# Altium schematic sheet USBDM_SWD_JS16CWJ.SchDoc(USBDM_SWD_JS16CWJ)
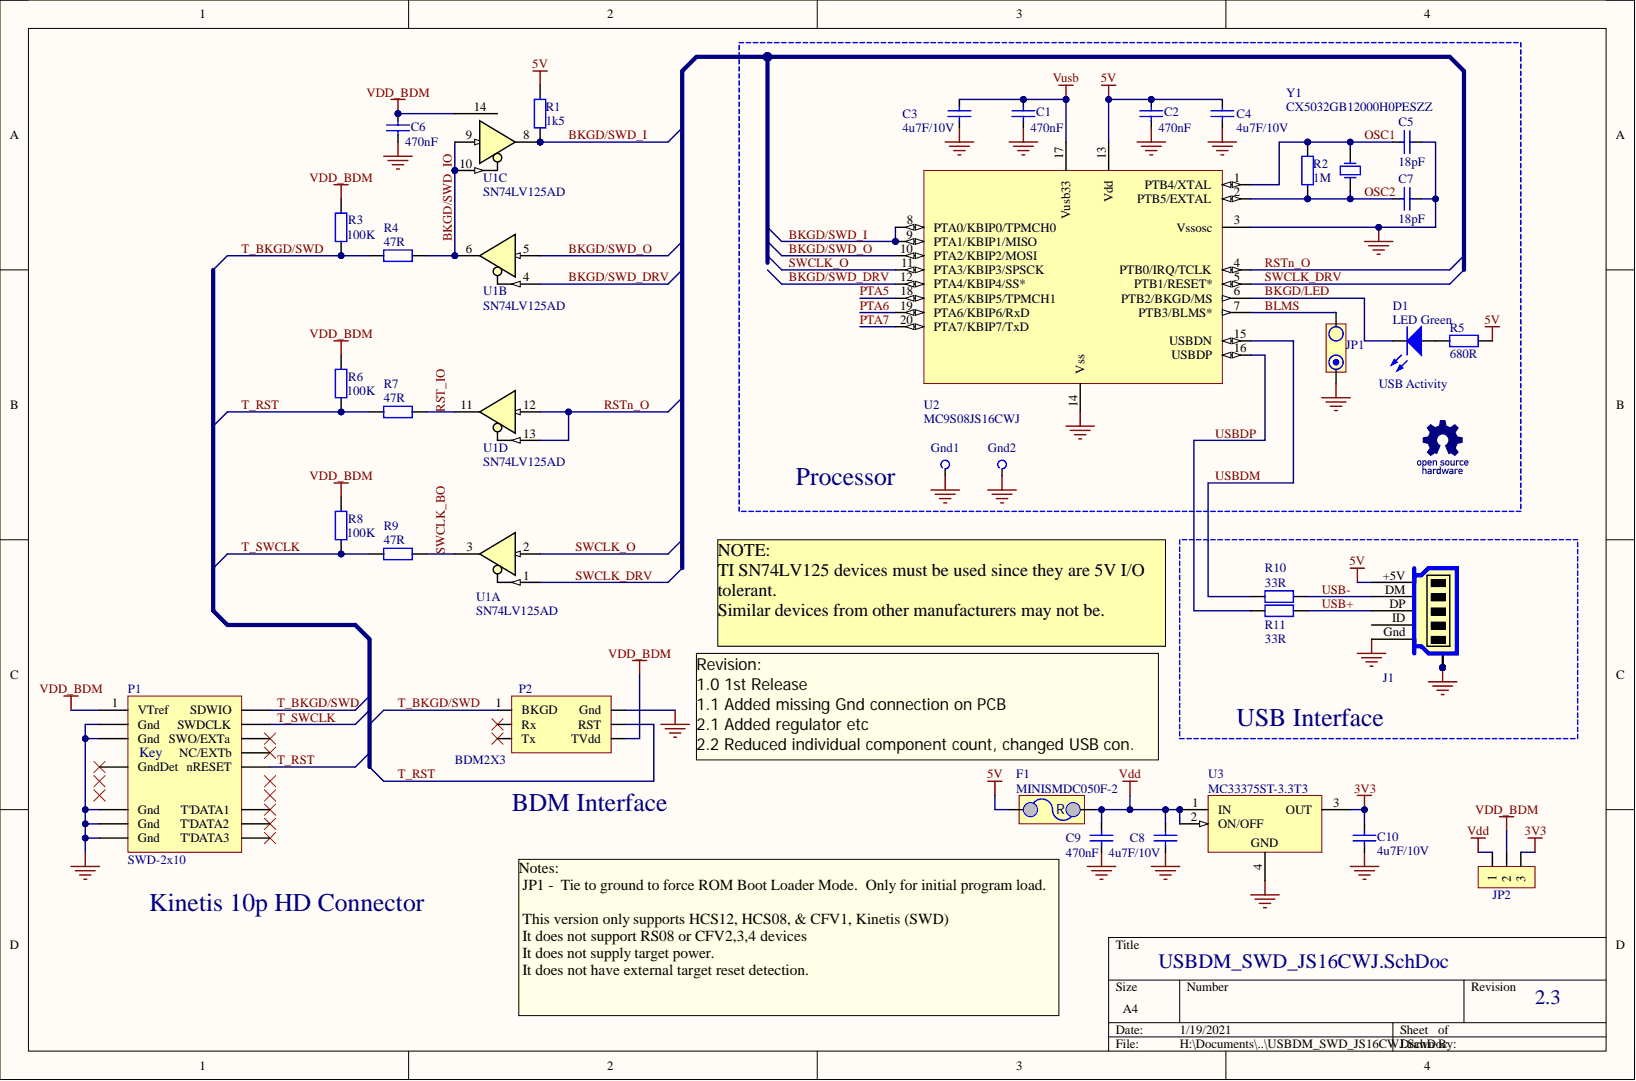
[diagram p0: USBDM_SWD_JS16CWJ.SchDoc]

## Parts
C1  470nF  [CCNP2012-0805]
C2  470nF  [CCNP2012-0805]
C3  4u7F/10V  [CCNP2012-0805]
C4  4u7F/10V  [CCNP2012-0805]
C5  18pF  [CCNP2012-0805]
C6  470nF  [CCNP2012-0805]
C7  18pF  [CCNP2012-0805]
C8  4u7F/10V  [CCNP2012-0805]
C9  470nF  [CCNP2012-0805]
C10  4u7F/10V  [CCNP2012-0805]
D1  LED Green  Class II: LED  [LED2012-0805-GREEN]
F1  MINISMDC050F-2  [PTC4532-1812]
Gnd1  TP  [PCBPIN - 0.9mm]  (value not drawn)  (no BOM)
Gnd2  TP  [PCBPIN - 0.9mm]  (value not drawn)  (no BOM)
J1  USB_MICRO_B_CONNECTOR  [MOLEX - 47346-0001]  (value not drawn)
JP1  PIN2X1  [HDR1X2S]  (value not drawn)
JP2  Header 1x3 2mm  [Header 1x3 (2mm)]  (value not drawn)
Logo1  OSHW Logo  [OSHW]  (value not drawn)  (no BOM)
P1  SWD-2x10  [SWD-2x10 - reduced]
P2  BDM2X3  [BDM]
R1  1k5  [CR2012-0805]
R2  1M  [CR2012-0805]
R3  100K  [CR2012-0805]
R4  47R  [CR2012-0805]
R5  680R  [CR2012-0805]
R6  100K  [CR2012-0805]
R7  47R  [CR2012-0805]
R8  100K  [CR2012-0805]
R9  47R  [CR2012-0805]
R10  33R  [CR2012-0805]
R11  33R  [CR2012-0805]
U1  SN74LV125AD  [SO-14_L]  (parts A,B,C,D)
U2  MC9S08JS16CWJ  [SO-G20]
U3  MC33375ST-3.3T3  [SOT223]
Y1  CX5032GB12000H0PESZZ  [XTAL_SMT - 5x3.2]

## Nets
3V3: C10.2 JP2.3 U3.3(OUT)
5V: C2.2 C4.2 F1.1 J1.VBUS R1.2 R5.2 U2.13(Vdd)
GND: C1.1 C2.1 C3.1 C4.1 C5.1 C6.1 C7.1 C8.1 C9.1 C10.1 Gnd1.1 Gnd2.1 J1.G J1.S1 J1.S2 J1.S3 J1.S4 J1.S5 J1.S6 JP1.1 P1.3(Gnd) P1.5(Gnd) P1.15(Gnd) P1.17(Gnd) P1.19(Gnd) P2.2(Gnd) U1.7 U2.3(Vssosc) U2.14(Vss) U3.4
Vdd: C8.2 C9.2 F1.2 JP2.1 U3.1(IN) U3.2(ON/OFF)
VDD_BDM: C6.2 JP2.2 P1.1(VTref) P2.6(TVdd) R3.1 R6.1 R8.1 U1.14
Vusb: C1.2 C3.2 U2.17(Vusb33)
BKGD/LED: D1.K U2.6(PTB2/BKGD/MS)
BKGD/SWD_DRV: U1.4 U2.12(PTA4/KBIP4/SS*)
BKGD/SWD_I: R1.1 U1.8 U2.8(PTA0/KBIP0/TPMCH0) U2.9(PTA1/KBIP1/MISO)
BKGD/SWD_IO: R4.1 U1.6 U1.9 U1.10
BKGD/SWD_O: U1.5 U2.10(PTA2/KBIP2/MOSI)
BLMS: JP1.2 U2.7(PTB3/BLMS*)
OSC1: C5.2 R2.2 U2.1(PTB4/XTAL) Y1.2
OSC2: C7.2 R2.1 U2.2(PTB5/EXTAL) Y1.1
PTA5: U2.18(PTA5/KBIP5/TPMCH1)
PTA6: U2.19(PTA6/KBIP6/RxD)
PTA7: U2.20(PTA7/KBIP7/TxD)
RST_IO: R7.1 U1.11
RSTn_O: U1.12 U1.13 U2.4(PTB0/IRQ/TCLK)
SWCLK_BO: R9.1 U1.3
SWCLK_DRV: U1.1 U2.5(PTB1/RESET*)
SWCLK_O: U1.2 U2.11(PTA3/KBIP3/SPSCK)
T_BKGD/SWD: P1.2(SDWIO) P2.1(BKGD) R3.2 R4.2
T_RST: P1.10(nRESET) P2.4(RST) R6.2 R7.2
T_SWCLK: P1.4(SWDCLK) R8.2 R9.2
USB+: J1.D+ R11.1
USB-: J1.D- R10.1
USBDM: R10.2 U2.15(USBDN)
USBDP: R11.2 U2.16(USBDP)
NetD1_A: D1.A R5.1

Not connected: J1 ID; P1 6(SWO/EXTa) 8(NC/EXTb) 9(GndDet) 16(T'DATA1) 18(T'DATA2) 20(T'DATA3); P2 3(Rx) 5(Tx)

## Library data of the parts
C1 C2 C5-C7 C9 = Cap: Capacitor SMT 0805; Manufacturer: Generic Components; Class: Passive; Component Kind: Standard; Library Name: MyLibrary.IntLib; Published: 08/06/2000; Signal Integrity: Cap; Simulation: CAP; SubClass: Capacitor; Publisher: Altium Hobart Technology Centre
  C1 C2 C6 C9: Farnell PN: 2497003; Value: 470nF
  C5 C7: Farnell PN: 2280674; Value: 18pF
C3 C4 C8 C10 = Cap_CCNP_0805: Capacitor SMT 0805; Manufacturer: Generic Components; Class: Passive; Component Kind: Standard; Farnell PN: 1327701; Library Name: MyLibrary.IntLib; Published: 08/06/2000; Signal Integrity: Cap; Simulation: CAP; SubClass: Capacitor; Value: 4u7F/10V; Publisher: Altium Hobart Technology Centre
D1 = LED-0805-Green: LED 0805 Green; Manufacturer: Generic Components; Class I: Optoelectronic; Component Kind: Standard; Farnell PN: 5790852; Library Name: MyLibrary.IntLib; Published: 8-Jun-2000; Revision: July-2002: Re-released for DXP Platform.; Publisher: Altium Limited
F1 = MINISMDC050F-2: PolySwitch miniSMDC Series, 500 mA, 1 A, 24 VDC; Component Kind: Standard; Farnell PN: 1175839; Library Name: MyLibrary.IntLib
Gnd1 Gnd2 = TP: Test Point; Library Name: MyLibrary.IntLib
J1 = USB_MICRO_B_CONNECTOR: USB Micro-B Connector; Component Kind: Standard; Farnell PN: 1568026; Library Name: MyLibrary.IntLib
JP2 = Header 1x3 2mm: Header, 1x3, 2mm; Manufacturer: Generic Components; Class I: Connector; Component Kind: Standard; Library Name: MySchLib.schlib; Revision: July-2002: Re-released for DXP Platform.; Signal Integrity: Connector; Publisher: Altium Limited
P1 = SWD2X10-Reduced: SWD Connector 2x10-Pin; Component Kind: Standard; Farnell PN: 1865289; LatestRevisionDate: 17-Jul-2002; LatestRevisionNote: Re-released for DXP Platform.; Library Name: MyLibrary.IntLib; Signal Integrity: Connector; Publisher: Altium Limited
P2 = BDM2X3: HCS12 BDM connector; Component Kind: Standard; Library Name: MyLibrary.IntLib
R1-R11 = Res: Resistor SMT; Manufacturer: Generic Components; Class: Passive; Component Kind: Standard; Library Name: MyLibrary.IntLib; Published: 08/06/2000; Signal Integrity: Res; Simulation: RESISTOR; SubClass: Resistor; Publisher: Altium Hobart Technology Centre
  R1: Farnell PN: 1632464; Value: 1k5
  R2: Farnell PN: 9236392; Value: 1M
  R3: Farnell PN: 9332405; Value: 100K
  R4 R7 R9: Farnell PN: 1632490; Value: 47R
  R5: Farnell PN: 9334785; Value: 680R
  R6 R8: Value: 100K
  R10 R11: Farnell PN: 1631399; Value: 33R
U1 = 74LV125AD: Quad 3-state Buffer; Component Kind: Standard; Digikey: 296-1674-5-ND; Farnell PN: 9592504; Library Name: MyLibrary.IntLib; Supplier 1: Digi-Key; Supplier Part Number 1: 296-1674-5-ND
U2 = MC9S08JS16CWJ: Microcontroller with USB; Component Kind: Standard; Farnell PN: 1704517; Library Name: MyLibrary.IntLib
U3 = MC33375ST-3.3T3: Low Dropout 300mA Voltage Regulator with ON/OFF Control; Component Kind: Standard; ComponentLink1Description: Manufacturer Link; ComponentLink1URL: http://www.onsemi.com/site/products/taxonomy/0,4438,503,00.html; ComponentLink2Description: Datasheet; ComponentLink2URL: http://www.onsemi.com/pub/Collateral/MC33375-D.PDF; DatasheetVersion: Mar-2000; Farnell PN: 1150444; Library Name: MyLibrary.IntLib; PackageDescription: SOT-223/SC-73; 4 Leads; Body 6.7 x 7.3 mm, inc. leads (LxW max); PackageReference: 318E-04; PackageVersion: Nov-1999; Published: 15-Apr-2002; Publisher: Altium Limited
Y1 = Crystal - CX5032GB12000H0PESZZ: AVX CRYSTAL, SM, CX-53F, 12MHZ; Manufacturer: Generic Components; Class: Oscillator; SubClass: Crystal Oscillator; Component Kind: Standard; Farnell PN: 1368769; Library Name: MyLibrary.IntLib; Published: 08/06/2000; Publisher: Altium Hobart Technology Centre
JP1 = PIN2X1: Component Kind: Standard; Library Name: MySchLib.schlib
Logo1 = OSHW Logo: Library Name: MySchLib.schlib
